# Altium schematic sheet STM32F10RBT6通用开发板_电源.SchDoc
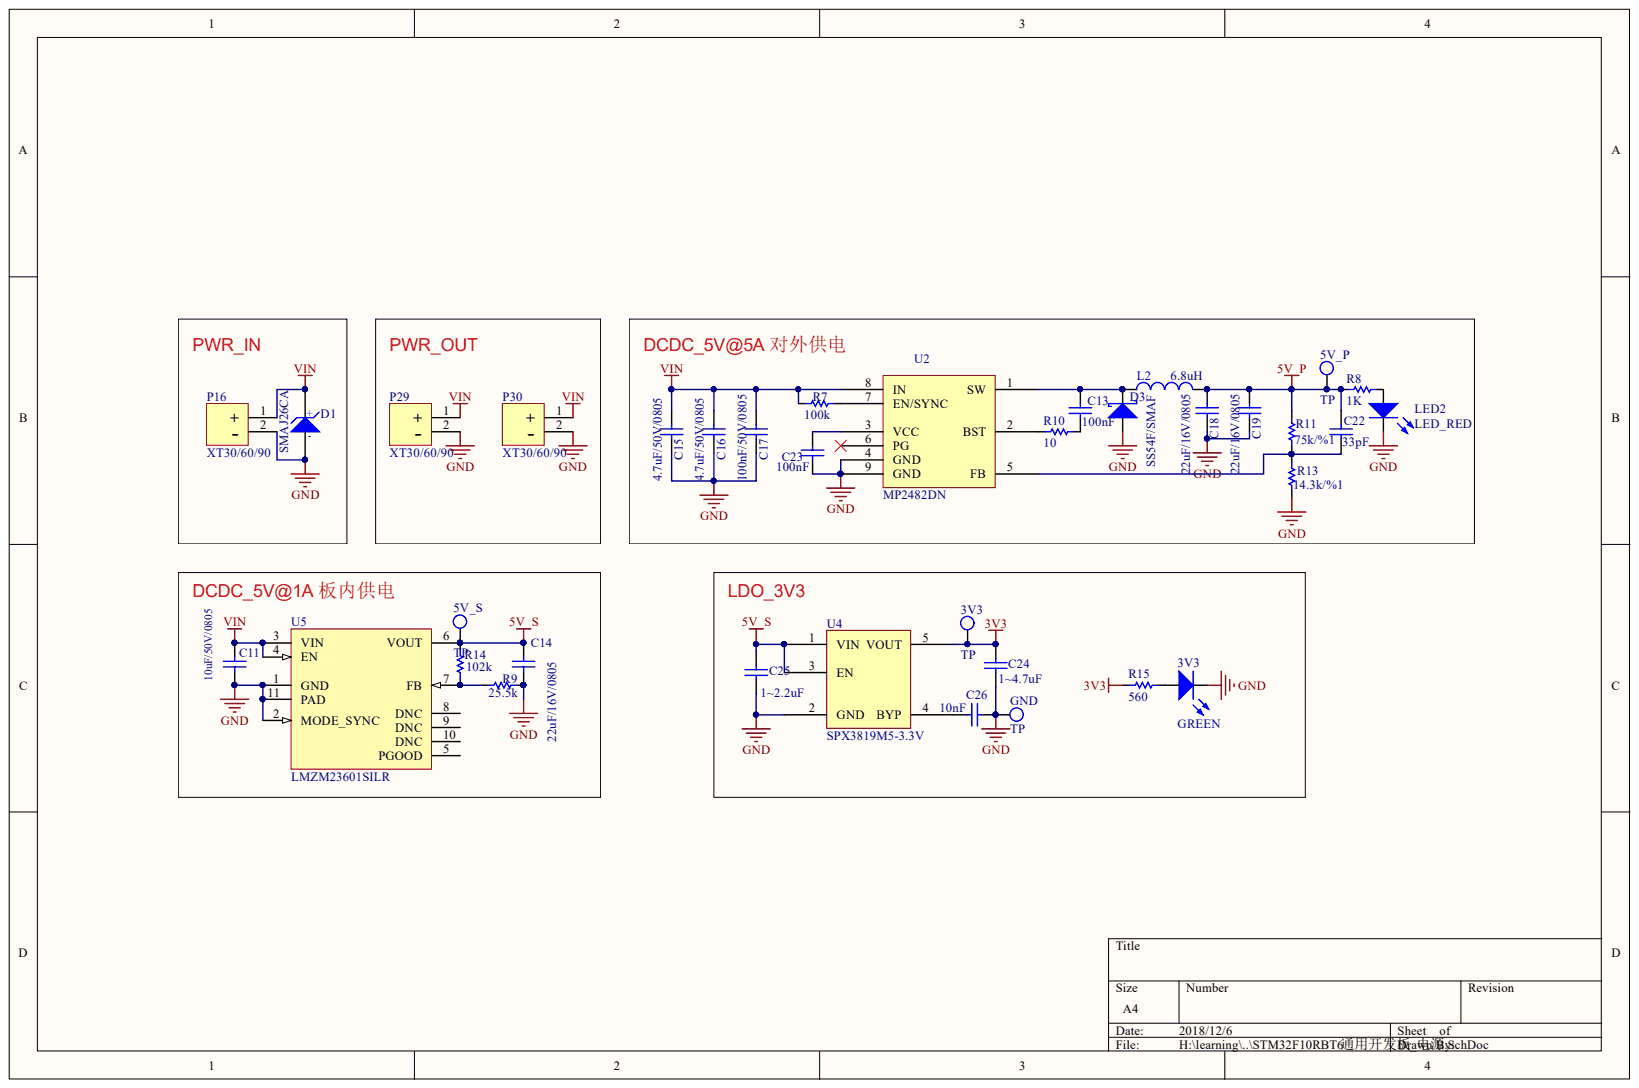
[diagram p0: STM32F10RBT6通用开发板_电源.SchDoc]

## Parts
3V3  TP  [TP_SMT]
3V3  GREEN  [0603LED_G]
5V_P  TP  [TP_SMT]
5V_S  TP  [TP_SMT]
C11  10uF/50V/0805  [0805]
C13  100nF  [0603C]
C14  22uF/16V/0805  [0805]
C15  4.7uF/50V/0805  [0805]
C16  4.7uF/50V/0805  [0805]
C17  100nF/50V/0805  [0603C]
C18  22uF/16V/0805  [0805]
C19  22uF/16V/0805  [0805]
C22  33pF  [0603C]
C23  100nF  [0603C]
C24  1~4.7uF  [0603C]
C25  1~2.2uF  [0603C]
C26  10nF  [0603C]
D1  SMAJ26CA  [SMA/DO-214AC]
D3  SS54F/SMAF  [SMAF/DO-214AC]
GND  TP  [TP_VIA]
L2  6.8uH  [0630L]
LED2  LED_RED  [0603LED_R]
P16  XT30/60/90  [XT30PW-Male]
P29  XT30/60/90  [XT30立式]
P30  XT30/60/90  [XT30立式]
R7  100k  [0603R]
R8  1K  [0603R]
R9  25.5k  [0603R]
R10  10  [0603R]
R11  75k/%1  [0603R]
R13  14.3k/%1  [0603R]
R14  102k  [0603R]
R15  560  [0603R]
U2  MP2482DN  [SOIC8E]
U4  SPX3819M5-3.3V  [SOT-23-5 L]
U5  LMZM23601SILR  [SIL0010A]

## Nets
3V3: 3V3.1 C24.1 R15.2 U4.5(VOUT)
5V_P: 5V_P.1 C18.2 C19.2 C22.2 L2.2 R8.1 R11.2
5V_S: 5V_S.1 C14.2 C25.2 R14.2 U4.1(VIN) U4.3(EN) U5.6(VOUT)
GND: 3V3.2 C11.1 C14.1 C15.1 C16.1 C17.1 C18.1 C19.1 C23.2 C24.2 C25.1 C26.1 D1.1 D3.1 GND.1 LED2.2 P16.2 P29.2 P30.2 R9.1 R13.1 U2.4(GND) U2.9(GND) U4.2(GND) U5.1(GND) U5.2(MODE_SYNC) U5.11(PAD)
VIN: C11.2 C15.2 C16.2 C17.2 D1.2 P16.1 P29.1 P30.1 R7.1 U2.8(IN) U5.3(VIN) U5.4(EN)
Net3V3_1: 3V3.1 R15.1
NetC13_1: C13.1 R10.1
NetC13_2: C13.2 D3.2 L2.1 U2.1(SW)
NetC22_1: C22.1 R11.1 R13.2 U2.5(FB)
NetC23_1: C23.1 U2.3(VCC)
NetC26_2: C26.2 U4.4(BYP)
NetLED2_1: LED2.1 R8.2
NetR7_2: R7.2 U2.7(EN/SYNC)
NetR9_2: R9.2 R14.1 U5.7(FB)
NetR10_2: R10.2 U2.2(BST)

Not connected: U2 6(PG); U5 5(PGOOD) 8(DNC) 9(DNC) 10(DNC)

## Library data of the parts
3V3 5V_P 5V_S GND = TP: Test Point; Component Kind: Standard; Library Name: MyComponents_v2.5.IntLib
3V3 LED2 = LED: Typical RED, GREEN, YELLOW, AMBER GaAs LED; Component Kind: Standard; LatestRevisionDate: 17-Jul-2002; LatestRevisionNote: Re-released for DXP Platform.; PackageDescription: SM LED; 2 Flat Leads; PackageReference: 3.2X1.6X1.1; PackageVersion: Feb-2002; Published: 8-Jun-2000; Simulation: LED2; Publisher: Altium Limited
  3V3: Library Name: MyComponents.IntLib
  LED2: Library Name: MyComponents_v2.5.IntLib
C11 C13-C19 C22-C26 = Cap: Capacitor; Value: 100pF; Component Kind: Standard; LatestRevisionDate: 17-Jul-2002; LatestRevisionNote: Re-released for DXP Platform.; PackageDescription: Radial Cap, Thru-Hole; 2 Leads; 0.3 in Pin Spacing; PackageReference: RAD-0.3; Published: 8-Jun-2000; Signal Integrity: Cap; Simulation: CAP; Publisher: Altium Limited
  C11 C14 C24-C26: Library Name: MyComponents_v2.4.IntLib
  C13 C15-C19 C22 C23: Library Name: MyComponents_v2.3.IntLib
D1 = TVS(单向）: Component Kind: Standard; Library Name: MyComponents_v2.4.IntLib
D3 = D Schottky: Schottky Diode; Code_JEDEC: DO-214-AA; Component Kind: Standard; LatestRevisionDate: 17-Jul-2002; LatestRevisionNote: Re-released for DXP Platform.; Library Name: MyComponents_v2.5.IntLib; PackageDescription: DO-214-AA/SMB; 2 C-Bend Leads; Body 5.3 x 3.6 mm, inc. leads (LxW); PackageReference: SMB; Published: 8-Jun-2000; Signal Integrity: D Schottky; Simulation: SKYDIODE; Publisher: Altium Limited
L2 = Inductor: Code_IEC: 1005; Value: 10mH; Code_JEITA: 0402; Component Kind: Standard; Description: Inductor; LatestRevisionDate: 29-May-2009; LatestRevisionNote: IPC-7351 Footprint Added.; Library Name: MyComponents_v2.3.IntLib; PackageDescription: Chip Inductor; PackageReference: 0402-A; Published: 8-Jun-2000; Signal Integrity: Inductor; Simulation: INDUCTOR; Publisher: Altium Limited
R7 R9-R11 R13-R15 = Res1: Resistor; Value: 1K; Component Kind: Standard; LatestRevisionDate: 17-Jul-2002; LatestRevisionNote: Re-released for DXP Platform.; PackageDescription: Axial Device, Thru-Hole; 2 Leads; 0.3 in Pin Spacing; PackageReference: AXIAL-0.3; Published: 8-Jun-2000; Signal Integrity: Res; Simulation: RESISTOR; Publisher: Altium Limited
  R7 R10 R11 R13: Library Name: MyComponents_v2.3.IntLib
  R9 R14: Library Name: MyComponents_v2.4.IntLib
  R15: Library Name: MyComponents.IntLib
R8 = Res1: Resistor; Component Kind: Standard; LatestRevisionDate: 17-Jul-2002; LatestRevisionNote: Re-released for DXP Platform.; Library Name: MyComponents_v2.5.IntLib; PackageDescription: Axial Device, Thru-Hole; 2 Leads; 0.3 in Pin Spacing; PackageReference: AXIAL-0.3; Published: 8-Jun-2000; Signal Integrity: Res; Simulation: RESISTOR; Value: 1K; Publisher: Altium Limited
U2 = MP2482DN: 开关电源5A; Component Kind: Standard; Library Name: MyComponents_v2.3.IntLib
U4 = SPX5205M5-3.3V: Component Kind: Standard; Library Name: MyComponents_v2.4.IntLib
U5 = LMZM23601SILR: Imported; Manufacturer: Texas Instruments; Component Kind: Standard; Library Name: MyComponents_v2.5.IntLib; PartNumber: LMZM23601SILR; RefDes: RefDes; TYPE: TYPE; Value: Value
P16 P29 P30 = XT30/60/90: Component Kind: Standard; Library Name: MyComponents_v2.4.IntLib
